# Altium schematic sheet test1.4.SchDoc(test1.4)
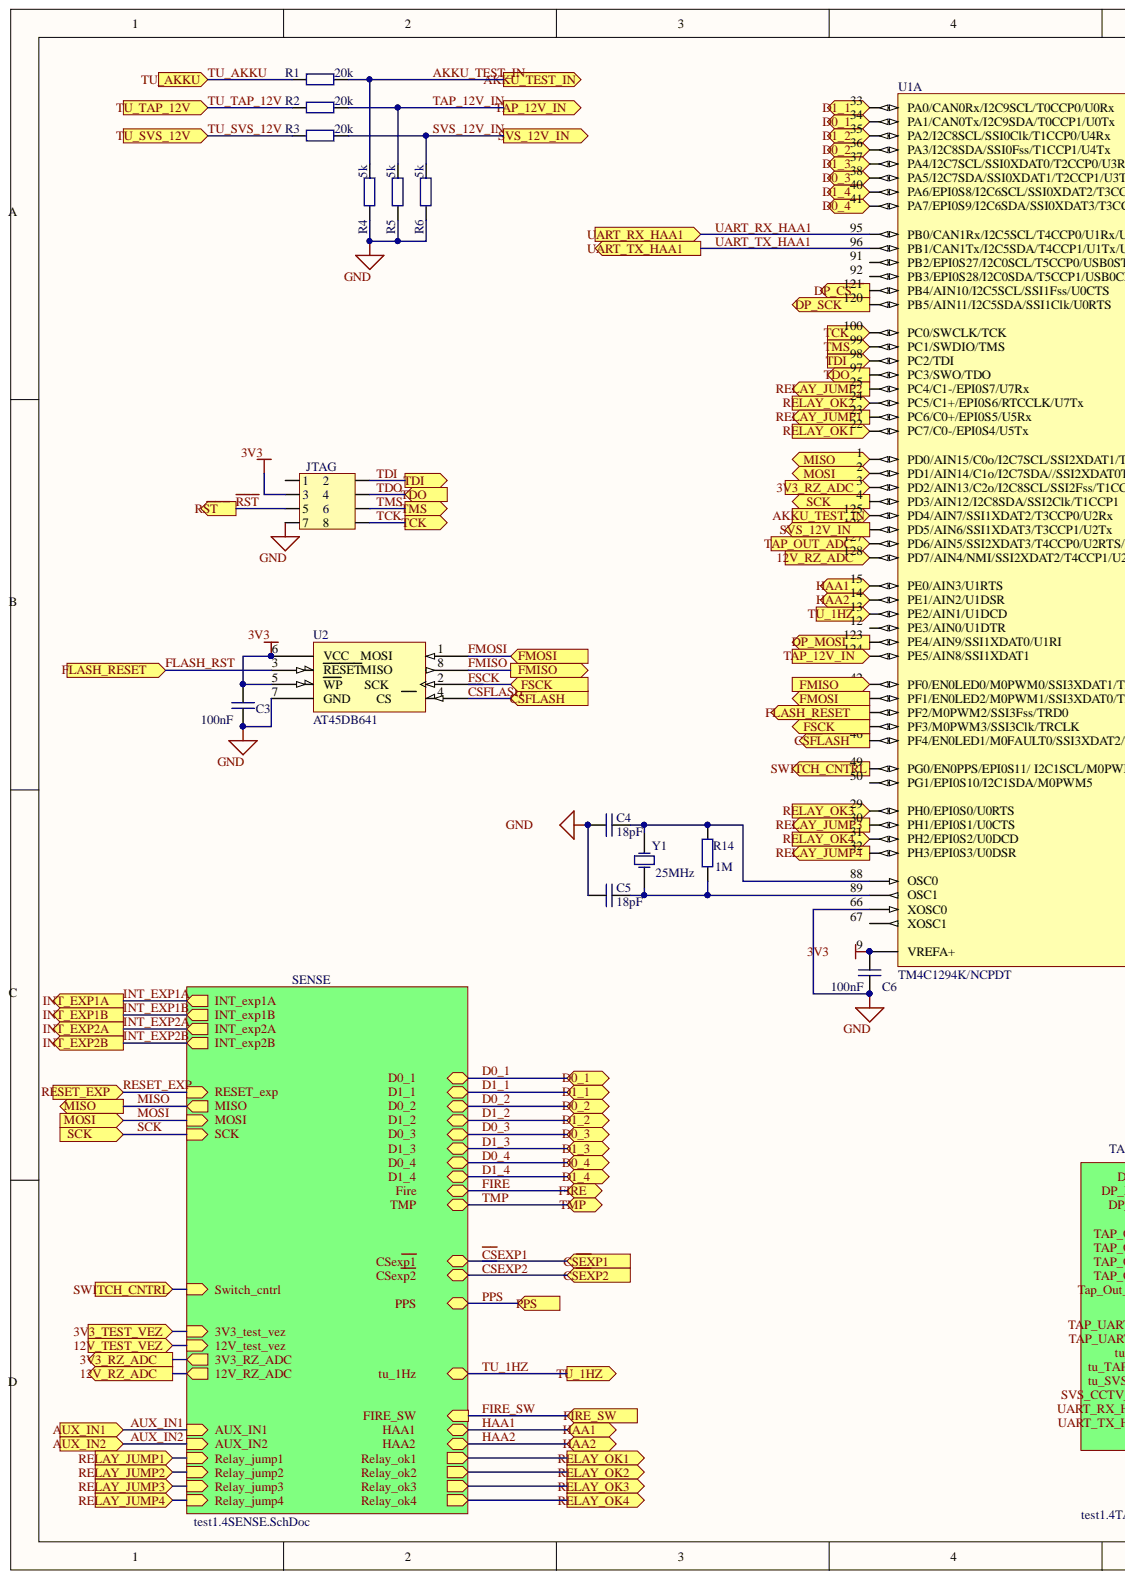
[diagram p0: test1.4.SchDoc, left]
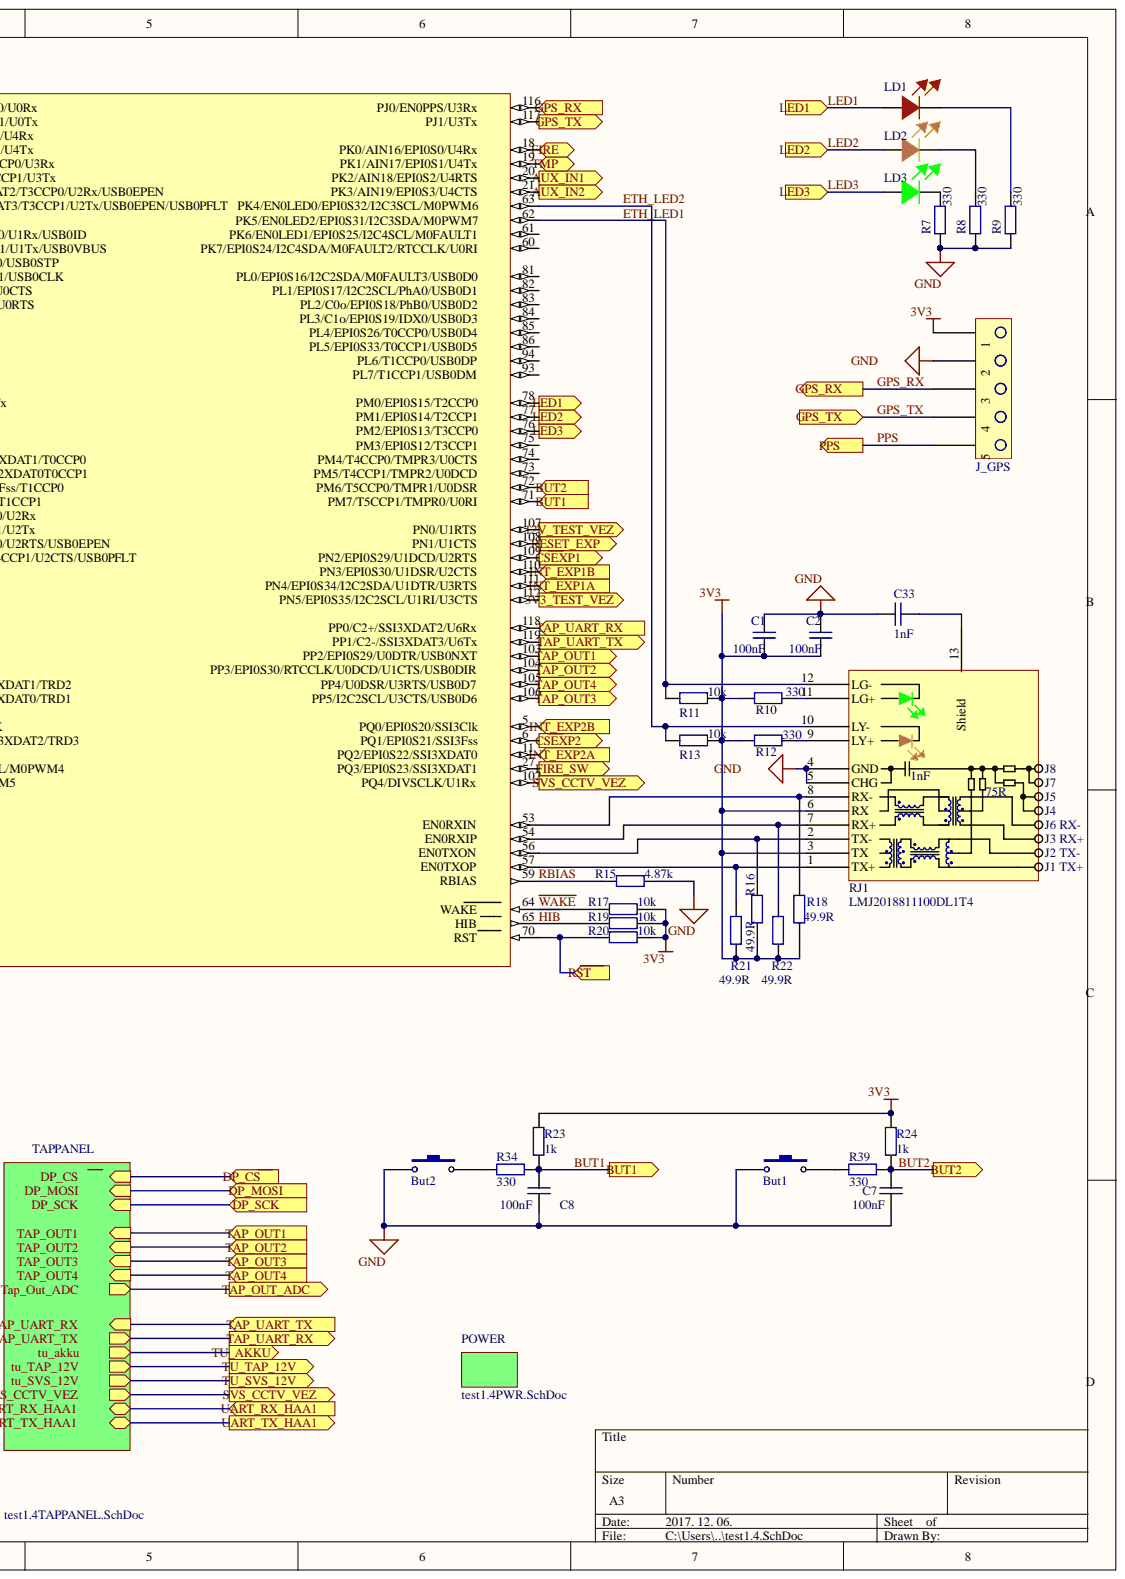
[diagram p1: test1.4.SchDoc, right]

## Parts
But1  1301.9302  [gen_switch_tactile]  (value not drawn)
But2  1301.9302  [gen_switch_tactile]  (value not drawn)
C1  value 100nF  [0603_N]  (hidden comment: Cap)
C2  value 100nF  [0603_N]  (hidden comment: Cap)
C3  value 100nF  [0603_N]  (hidden comment: Cap)
C4  value 18pF  [0603_N]  (hidden comment: Cap)
C5  value 18pF  [0603_N]  (hidden comment: Cap)
C6  value 100nF  [0603_N]  (hidden comment: Cap)
C7  value 100nF  [0603_N]  (hidden comment: Cap)
C8  value 100nF  [0603_N]  (hidden comment: Cap)
C33  value 1nF  [CAP_C1206]  (hidden comment: Cap)
J_GPS  2,54mm  [gen_io_pin5]  (value not drawn)
JTAG  hdr_2x4  [CON_IDC8ver2]  (value not drawn)
LD1  gen_LED_red  [LED_0805 - duplicate]  (value not drawn)
LD2  gen_LED_amber  [LED_0805 - duplicate]  (value not drawn)
LD3  gen_LED_green  [LED_0805 - duplicate]  (value not drawn)
R1  value 20k  [0603_N]  (hidden comment: Resistor)
R2  value 20k  [0603_N]  (hidden comment: Resistor)
R3  value 20k  [0603_N]  (hidden comment: Resistor)
R4  value 5k  [0603_N]  (hidden comment: Resistor)
R5  value 5k  [0603_N]  (hidden comment: Resistor)
R6  value 5k  [0603_N]  (hidden comment: Resistor)
R7  value 330  [0603_N]  (hidden comment: Resistor)
R8  value 330  [0603_N]  (hidden comment: Resistor)
R9  value 330  [0603_N]  (hidden comment: Resistor)
R10  value 330  [0603_N]  (hidden comment: Resistor)
R11  value 10k  [0603_N]  (hidden comment: Resistor)
R12  value 330  [0603_N]  (hidden comment: Resistor)
R13  value 10k  [0603_N]  (hidden comment: Resistor)
R14  value 1M  [0603_N]  (hidden comment: Resistor)
R15  value 4.87k  [0805_N]  (hidden comment: Resistor)
R16  value 49.9R  [0603_N]  (hidden comment: Resistor)
R17  value 10k  [0603_N]  (hidden comment: Resistor)
R18  value 49.9R  [0603_N]  (hidden comment: Resistor)
R19  value 10k  [0603_N]  (hidden comment: Resistor)
R20  value 10k  [0603_N]  (hidden comment: Resistor)
R21  value 49.9R  [0603_N]  (hidden comment: Resistor)
R22  value 49.9R  [0603_N]  (hidden comment: Resistor)
R23  value 1k  [0603_N]  (hidden comment: Resistor)
R24  value 1k  [0603_N]  (hidden comment: Resistor)
R34  value 330  [0603_N]  (hidden comment: Resistor)
R39  value 330  [0603_N]  (hidden comment: Resistor)
RJ1  LMJ2018811100DL1T4  [con_RJ45_LPJ1026BHNL - duplicate]
U1  TM4C1294K/NCPDT  [TQFP128]  (part A)
U2  AT45DB641  [SO8W_ver1]
Y1  25MHz  [XTAL_THT_HC49S_ver1]

## Ports
3V3_RZ_ADC, 3V3_TEST_VEZ, 12V_RZ_ADC, 12V_TEST_VEZ, AKKU_TEST_IN, AUX_IN1, AUX_IN2, BUT1, BUT2, D0_1, D0_2, D0_3, D0_4, D1_1, D1_2, D1_3, D1_4, DP_MOSI, DP_SCK, DP_~{CS}, FIRE, FIRE_SW, FLASH_RESET, FMISO, FMOSI, FSCK, GPS_RX, GPS_TX, HAA1, HAA2, INT_EXP1A, INT_EXP1B, INT_EXP2A, INT_EXP2B, LED1, LED2, LED3, MISO, MOSI, PPS, RELAY_JUMP1, RELAY_JUMP2, RELAY_JUMP3, RELAY_JUMP4, RELAY_OK1, RELAY_OK2, RELAY_OK3, RELAY_OK4, RESET_EXP, SCK, SVS_12V_IN, SVS_CCTV_VEZ, SWITCH_CNTRL, TAP_12V_IN, TAP_OUT1, TAP_OUT2, TAP_OUT3, TAP_OUT4, TAP_OUT_ADC, TAP_UART_RX, TAP_UART_TX, TCK, TDI, TDO, TMP, TMS, TU_1HZ, TU_AKKU, TU_SVS_12V, TU_TAP_12V, UART_RX_HAA1, UART_TX_HAA1, ~{CS}EXP1, ~{CS}EXP2, ~{CS}FLASH, ~{RST}

## Nets
3V3: C1.2 C2.2 C3.1 C6.1 J_GPS.1 JTAG.3 R10.2 R11.2 R12.2 R13.2 R16.1 R17.2 R18.1 R19.2 R20.2 R21.1 R22.1 R23.2 R24.2 RJ1.3(TX) RJ1.6(RX) U1.9(VREFA+) U2.5(WP) U2.6(VCC)
GND: But1.1 But2.1 C1.1 C2.1 C3.2 C4.2 C5.2 C6.2 C7.2 C8.2 C33.1 J_GPS.2 JTAG.7 R4.1 R5.1 R6.1 R7.1 R8.1 R9.1 R15.2 RJ1.4(GND) RJ1.5(CHG) U1.66(XOSC0) U2.7(GND)
3V3_RZ_ADC: U1.3(PD2/AIN13/C2o/I2C8SCL/SSI2Fss/T1CCP0) ; port 3V3_RZ_ADC
12V_RZ_ADC: U1.128(PD7/AIN4/NMI/SSI2XDAT2/T4CCP1/U2CTS/USB0PFLT) ; port 12V_RZ_ADC
AKKU_TEST_IN: R1.2 R4.2 U1.125(PD4/AIN7/SSI1XDAT2/T3CCP0/U2Rx) ; port AKKU_TEST_IN
AUX_IN1: U1.20 ; port AUX_IN1
AUX_IN2: U1.21 ; port AUX_IN2
BUT1: C8.1 R23.1 R34.2 U1.71 ; port BUT1
BUT2: C7.1 R24.1 R39.1 U1.72 ; port BUT2
~{CS}EXP1: U1.109 ; port ~{CS}EXP1
~{CS}EXP2: U1.6 ; port ~{CS}EXP2
~{CS}FLASH: U1.46(PF4/EN0LED1/M0FAULT0/SSI3XDAT2/TRD3) U2.4 ; port ~{CS}FLASH
D0_1: U1.34(PA1/CAN0Tx/I2C9SDA/T0CCP1/U0Tx) ; port D0_1
D0_2: U1.36(PA3/I2C8SDA/SSI0Fss/T1CCP1/U4Tx) ; port D0_2
D0_3: U1.38(PA5/I2C7SDA/SSI0XDAT1/T2CCP1/U3Tx) ; port D0_3
D0_4: U1.41(PA7/EPI0S9/I2C6SDA/SSI0XDAT3/T3CCP1/U2Tx/USB0EPEN/USB0PFLT) ; port D0_4
D1_1: U1.33(PA0/CAN0Rx/I2C9SCL/T0CCP0/U0Rx) ; port D1_1
D1_2: U1.35(PA2/I2C8SCL/SSI0Clk/T1CCP0/U4Rx) ; port D1_2
D1_3: U1.37(PA4/I2C7SCL/SSI0XDAT0/T2CCP0/U3Rx) ; port D1_3
D1_4: U1.40(PA6/EPI0S8/I2C6SCL/SSI0XDAT2/T3CCP0/U2Rx/USB0EPEN) ; port D1_4
DP_~{CS}: U1.121(PB4/AIN10/I2C5SCL/SSI1Fss/U0CTS) ; port DP_~{CS}
DP_MOSI: U1.123(PE4/AIN9/SSI1XDAT0/U1RI) ; port DP_MOSI
DP_SCK: U1.120(PB5/AIN11/I2C5SDA/SSI1Clk/U0RTS) ; port DP_SCK
ETH_LED1: R11.1 RJ1.12(LG-) U1.62
ETH_LED2: R13.1 RJ1.10(LY-) U1.63
FIRE: U1.18 ; port FIRE
FIRE_SW: U1.27 ; port FIRE_SW
FLASH_RST: U1.44(PF2/M0PWM2/SSI3Fss/TRD0) U2.3(RESET) ; port FLASH_RESET
FMISO: U1.42(PF0/EN0LED0/M0PWM0/SSI3XDAT1/TRD2) U2.8 ; port FMISO
FMOSI: U1.43(PF1/EN0LED2/M0PWM1/SSI3XDAT0/TRD1) U2.1 ; port FMOSI
FSCK: U1.45(PF3/M0PWM3/SSI3Clk/TRCLK) U2.2 ; port FSCK
GPS_RX: J_GPS.3 U1.116 ; port GPS_RX
GPS_TX: J_GPS.4 U1.117 ; port GPS_TX
~{HIB}: R19.1 U1.65
HAA1: U1.15(PE0/AIN3/U1RTS) ; port HAA1
HAA2: U1.14(PE1/AIN2/U1DSR) ; port HAA2
INT_EXP1A: U1.111 ; port INT_EXP1A
INT_EXP1B: U1.110 ; port INT_EXP1B
INT_EXP2A: U1.11 ; port INT_EXP2A
INT_EXP2B: U1.5 ; port INT_EXP2B
LED1: LD1.1 U1.78 ; port LED1
LED2: LD2.1 U1.77 ; port LED2
LED3: LD3.1 U1.76 ; port LED3
MISO: U1.1(PD0/AIN15/C0o/I2C7SCL/SSI2XDAT1/T0CCP0) ; port MISO
MOSI: U1.2(PD1/AIN14/C1o/I2C7SDA//SSI2XDAT0T0CCP1) ; port MOSI
PPS: J_GPS.5 ; port PPS
~{RST}: JTAG.5 R20.1 U1.70 ; port ~{RST}
RBIAS: R15.1 U1.59
RESET_EXP: U1.108 ; port RESET_EXP
SCK: U1.4(PD3/AIN12/I2C8SDA/SSI2Clk/T1CCP1) ; port SCK
SVS_12V_IN: R3.2 R6.2 U1.126(PD5/AIN6/SSI1XDAT3/T3CCP1/U2Tx) ; port SVS_12V_IN
SVS_CCTV_VEZ: U1.102 ; port SVS_CCTV_VEZ
TAP_12V_IN: R2.2 R5.2 U1.124(PE5/AIN8/SSI1XDAT1) ; port TAP_12V_IN
TAP_OUT1: U1.103 ; port TAP_OUT1
TAP_OUT2: U1.104 ; port TAP_OUT2
TAP_OUT3: U1.106 ; port TAP_OUT3
TAP_OUT4: U1.105 ; port TAP_OUT4
TAP_UART_RX: U1.118 ; port TAP_UART_RX
TAP_UART_TX: U1.119 ; port TAP_UART_TX
TCK: JTAG.8 U1.100(PC0/SWCLK/TCK) ; port TCK
TDI: JTAG.2 U1.98(PC2/TDI) ; port TDI
TDO: JTAG.4 U1.97(PC3/SWO/TDO) ; port TDO
TMP: U1.19 ; port TMP
TMS: JTAG.6 U1.99(PC1/SWDIO/TMS) ; port TMS
TU_1HZ: U1.13(PE2/AIN1/U1DCD) ; port TU_1HZ
TU_AKKU: R1.1 ; port TU_AKKU
TU_SVS_12V: R3.1 ; port TU_SVS_12V
TU_TAP_12V: R2.1 ; port TU_TAP_12V
UART_RX_HAA1: U1.95(PB0/CAN1Rx/I2C5SCL/T4CCP0/U1Rx/USB0ID) ; port UART_RX_HAA1
UART_TX_HAA1: U1.96(PB1/CAN1Tx/I2C5SDA/T4CCP1/U1Tx/USB0VBUS) ; port UART_TX_HAA1
~{WAKE}: R17.1 U1.64
NetBut1_2: But1.2 R39.2
NetBut2_2: But2.2 R34.1
NetC4_1: C4.1 R14.2 U1.88(OSC0) Y1.2
NetC5_1: C5.1 R14.1 U1.89(OSC1) Y1.1
NetC33_2: C33.2 RJ1.13
NetJTAG_1: JTAG.1
NetLD1_2: LD1.2 R9.2
NetLD2_2: LD2.2 R8.2
NetLD3_2: LD3.2 R7.2
NetR10_1: R10.1 RJ1.11(LG+)
NetR12_1: R12.1 RJ1.9(LY+)
NetR16_2: R16.2 RJ1.2(TX-) U1.56
NetR18_2: R18.2 RJ1.8(RX-) U1.53
NetR21_2: R21.2 RJ1.1(TX+) U1.57
NetR22_2: R22.2 RJ1.7(RX+) U1.54
NetU1_12: U1.12(PE3/AIN0/U1DTR)
NetU1_22: U1.22(PC7/C0-/EPI0S4/U5Tx) ; port RELAY_OK1
NetU1_23: U1.23(PC6/C0+/EPI0S5/U5Rx) ; port RELAY_JUMP1
NetU1_24: U1.24(PC5/C1+/EPI0S6/RTCCLK/U7Tx) ; port RELAY_OK2
NetU1_25: U1.25(PC4/C1-/EPI0S7/U7Rx) ; port RELAY_JUMP2
NetU1_29: U1.29(PH0/EPI0S0/U0RTS) ; port RELAY_OK3
NetU1_30: U1.30(PH1/EPI0S1/U0CTS) ; port RELAY_JUMP3
NetU1_31: U1.31(PH2/EPI0S2/U0DCD) ; port RELAY_OK4
NetU1_32: U1.32(PH3/EPI0S3/U0DSR) ; port RELAY_JUMP4
NetU1_49: U1.49(PG0/EN0PPS/EPI0S11/_I2C1SCL/M0PWM4) ; port SWITCH_CNTRL
NetU1_50: U1.50(PG1/EPI0S10/I2C1SDA/M0PWM5)
NetU1_60: U1.60
NetU1_61: U1.61
NetU1_67: U1.67(XOSC1)
NetU1_73: U1.73
NetU1_74: U1.74
NetU1_75: U1.75
NetU1_81: U1.81
NetU1_82: U1.82
NetU1_83: U1.83
NetU1_84: U1.84
NetU1_85: U1.85
NetU1_86: U1.86
NetU1_91: U1.91(PB2/EPI0S27/I2C0SCL/T5CCP0/USB0STP)
NetU1_92: U1.92(PB3/EPI0S28/I2C0SDA/T5CCP1/USB0CLK)
NetU1_93: U1.93
NetU1_94: U1.94
NetU1_107: U1.107 ; port 12V_TEST_VEZ
NetU1_112: U1.112 ; port 3V3_TEST_VEZ
NetU1_127: U1.127(PD6/AIN5/SSI2XDAT3/T4CCP0/U2RTS/USB0EPEN) ; port TAP_OUT_ADC

## Library data of the parts
But1 But2 = SW-PB: Mikrokapcsoló; 1-pozíciós; SPST-NO; 0,05A/12VDC; THT; 1,6N; 6x6mm; Component Kind: Standard; Library Name: szoki.SchLib
C1-C8 = gen_capacitor: Capacitor; Voltage: 16V; Component Kind: Standard; Simulation: CAP; Library Name: szoki.SchLib
C33 = gen_capacitor: 1206GC102KAT1A; Voltage: 2kV; Component Kind: Standard; Simulation: CAP; Library Name: szoki.SchLib
J_GPS = gen_io_pin5: 2,54mm PIN header; Component Kind: Standard; Library Name: szoki.SchLib
LD1 = gen_LED_red: LITEON LTST-C170EKT, LED; SMD; 0805; piros; 1,12-3mcd; 2x1,25x1,1mm; 130°; 2÷2,6V; 10mA; Component Kind: Standard; Library Name: szoki.SchLib
LD2 = gen_LED_amber: LITEON LTST-C170YKT, LED; SMD; 0805; sárga; 1,12-2,5mcd; 2x1,25x1,1mm; 130°; 2,1÷2,6V; Component Kind: Standard; Library Name: szoki.SchLib
LD3 = gen_LED_green: LITEON LTST-C171GKT, LED; SMD; 0805; zöld; 1,8-6mcd; 2x1,25x0,8mm; 130°; 2,1÷2,4V; 10mA; Component Kind: Standard; Library Name: szoki.SchLib
R1-R24 R34 R39 = gen_resistor: Generic Resistor; Power: 0.125W; Tolerance: 1%; Component Kind: Standard; Simulation: Model Name; Library Name: szoki.SchLib
RJ1 = con_RJ45_LPJ1026BHNL: RJ45 connector with Ethernet transformer; Component Kind: Standard; Library Name: szoki.SchLib
U1 = TM4C1294: TM4C1294KCPDT/NCPDT; Component Kind: Standard; Library Name: szoki.SchLib
U2 = flash_memory_AT45DBxx1: Adesto Technologies SPI Serial Flash Memory DataFlash; Component Kind: Standard; Library Name: szoki.SchLib
Y1 = gen_xtal: Crystal Oscillator 25MHz +-50ppm HC49; Component Kind: Standard; Library Name: szoki.SchLib
JTAG = hdr_2x4: Component Kind: Standard; Library Name: szoki.SchLib
